# Altium schematic sheet fpga_ddr3l.SchDoc(fpga_ddr3l)
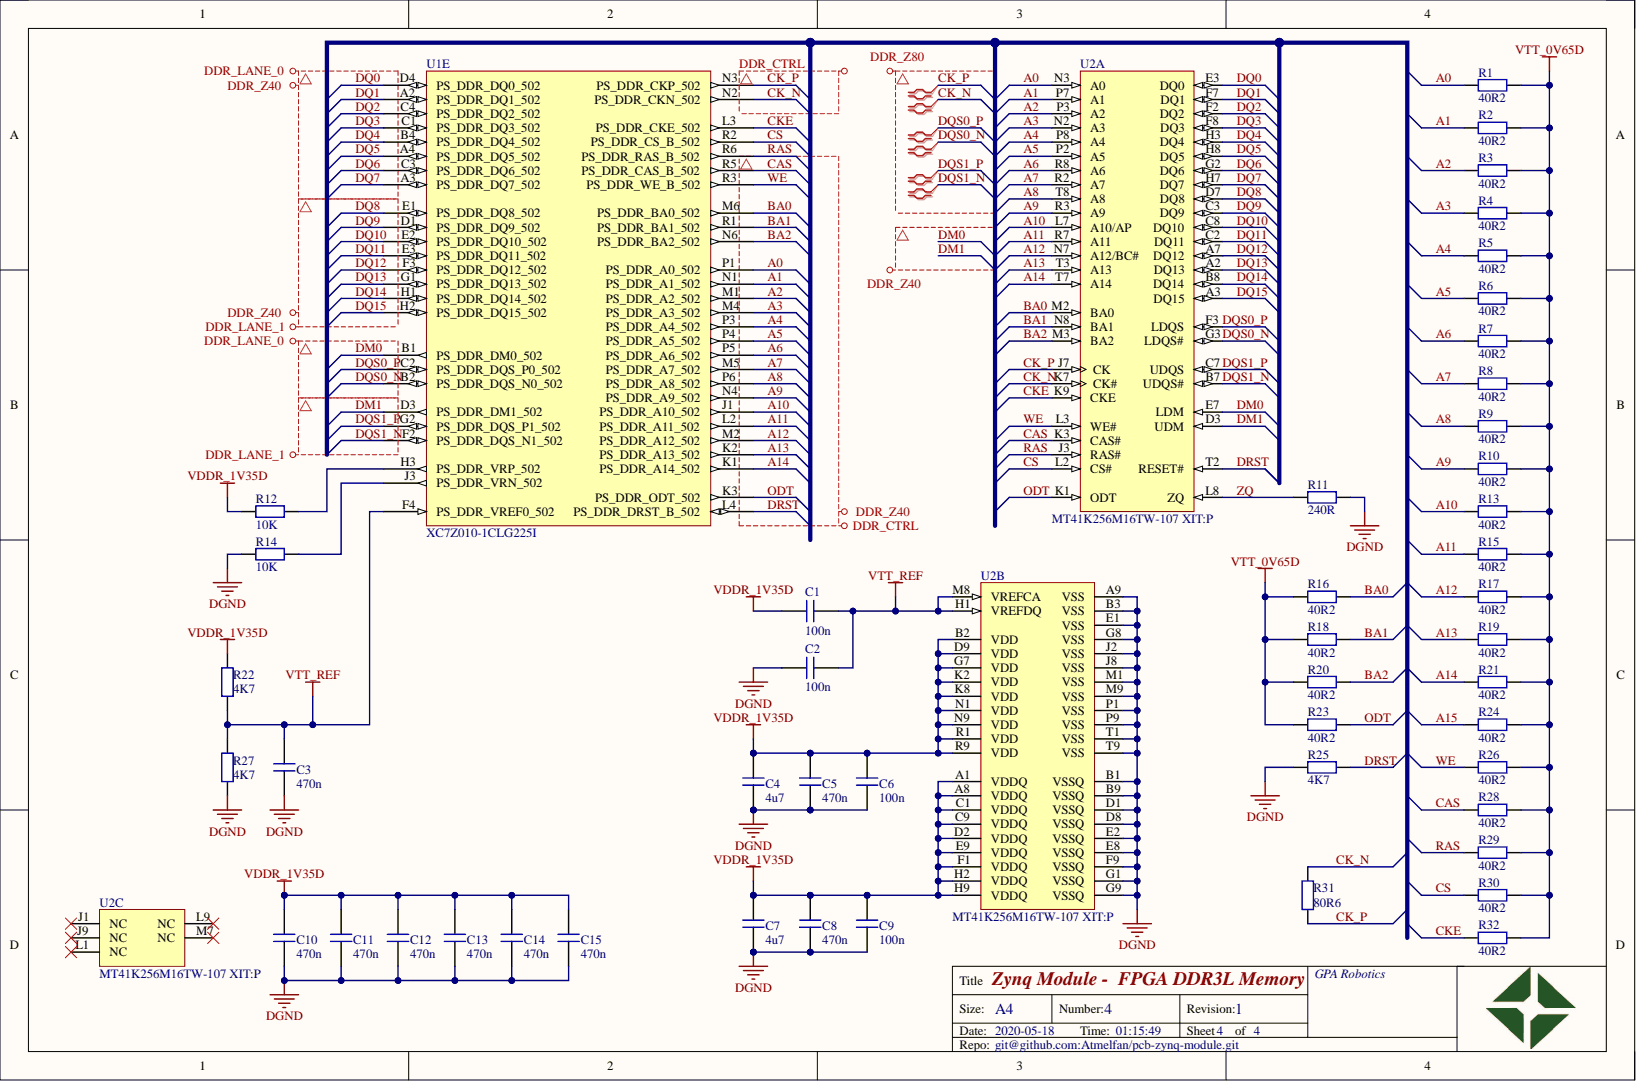
[diagram p0: fpga_ddr3l.SchDoc]

## Parts
C1  value 100n  [CAPC1005X06N]  (hidden comment: cap)
C2  value 100n  [CAPC1005X06N]  (hidden comment: cap)
C3  value 470n  [CAPC1608X08N]  (hidden comment: cap)
C4  value 4u7  [CAPC3216X14N]  (hidden comment: cap)
C5  value 470n  [CAPC1608X08N]  (hidden comment: cap)
C6  value 100n  [CAPC1005X06N]  (hidden comment: cap)
C7  value 4u7  [CAPC3216X14N]  (hidden comment: cap)
C8  value 470n  [CAPC1608X08N]  (hidden comment: cap)
C9  value 100n  [CAPC1005X06N]  (hidden comment: cap)
C10  value 470n  [CAPC1608X08N]  (hidden comment: cap)
C11  value 470n  [CAPC1608X08N]  (hidden comment: cap)
C12  value 470n  [CAPC1608X08N]  (hidden comment: cap)
C13  value 470n  [CAPC1608X08N]  (hidden comment: cap)
C14  value 470n  [CAPC1608X08N]  (hidden comment: cap)
C15  value 470n  [CAPC1608X08N]  (hidden comment: cap)
R1  value 40R2  [RESC1005X04N]  (hidden comment: res)
R2  value 40R2  [RESC1005X04N]  (hidden comment: res)
R3  value 40R2  [RESC1005X04N]  (hidden comment: res)
R4  value 40R2  [RESC1005X04N]  (hidden comment: res)
R5  value 40R2  [RESC1005X04N]  (hidden comment: res)
R6  value 40R2  [RESC1005X04N]  (hidden comment: res)
R7  value 40R2  [RESC1005X04N]  (hidden comment: res)
R8  value 40R2  [RESC1005X04N]  (hidden comment: res)
R9  value 40R2  [RESC1005X04N]  (hidden comment: res)
R10  value 40R2  [RESC1005X04N]  (hidden comment: res)
R11  value 240R  [RESC1005X04N]  (hidden comment: res)
R12  value 10K  [RESC1005X04N]  (hidden comment: res)
R13  value 40R2  [RESC1005X04N]  (hidden comment: res)
R14  value 10K  [RESC1005X04N]  (hidden comment: res)
R15  value 40R2  [RESC1005X04N]  (hidden comment: res)
R16  value 40R2  [RESC1005X04N]  (hidden comment: res)
R17  value 40R2  [RESC1005X04N]  (hidden comment: res)
R18  value 40R2  [RESC1005X04N]  (hidden comment: res)
R19  value 40R2  [RESC1005X04N]  (hidden comment: res)
R20  value 40R2  [RESC1005X04N]  (hidden comment: res)
R21  value 40R2  [RESC1005X04N]  (hidden comment: res)
R22  value 4K7  [RESC1005X04N]  (hidden comment: res)
R23  value 40R2  [RESC1005X04N]  (hidden comment: res)
R24  value 40R2  [RESC1005X04N]  (hidden comment: res)
R25  value 4K7  [RESC1005X04N]  (hidden comment: res)
R26  value 40R2  [RESC1005X04N]  (hidden comment: res)
R27  value 4K7  [RESC1005X04N]  (hidden comment: res)
R28  value 40R2  [RESC1005X04N]  (hidden comment: res)
R29  value 40R2  [RESC1005X04N]  (hidden comment: res)
R30  value 40R2  [RESC1005X04N]  (hidden comment: res)
R31  value 80R6  [RESC1005X04N]  (hidden comment: res)
R32  value 40R2  [RESC1005X04N]  (hidden comment: res)
U1  XC7Z010-1CLG225I  [CLG225]  (part E)
U2  MT41K256M16TW-107 XIT:P  [MICT-TW-96]  (parts A,B,C)

## Nets
DGND: C2.1 C3.1 C4.1 C5.1 C6.1 C7.1 C8.1 C9.1 C10.1 C11.1 C12.1 C13.1 C14.1 C15.1 R11.2 R14.1 R25.2 R27.1 U2.A9(VSS) U2.B1(VSSQ) U2.B3(VSS) U2.B9(VSSQ) U2.D1(VSSQ) U2.D8(VSSQ) U2.E1(VSS) U2.E2(VSSQ) U2.E8(VSSQ) U2.F9(VSSQ) U2.G1(VSSQ) U2.G8(VSS) U2.G9(VSSQ) U2.J2(VSS) U2.J8(VSS) U2.M1(VSS) U2.M9(VSS) U2.P1(VSS) U2.P9(VSS) U2.T1(VSS) U2.T9(VSS)
VDDR_1V35D: C1.1 C4.2 C5.2 C6.2 C7.2 C8.2 C9.2 C10.2 C11.2 C12.2 C13.2 C14.2 C15.2 R12.1 R22.2 U2.A1(VDDQ) U2.A8(VDDQ) U2.B2(VDD) U2.C1(VDDQ) U2.C9(VDDQ) U2.D2(VDDQ) U2.D9(VDD) U2.E9(VDDQ) U2.F1(VDDQ) U2.G7(VDD) U2.H2(VDDQ) U2.H9(VDDQ) U2.K2(VDD) U2.K8(VDD) U2.N1(VDD) U2.N9(VDD) U2.R1(VDD) U2.R9(VDD)
VTT_0V65D: R1.2 R2.2 R3.2 R4.2 R5.2 R6.2 R7.2 R8.2 R9.2 R10.2 R13.2 R15.2 R16.2 R17.2 R18.2 R19.2 R20.2 R21.2 R23.2 R24.2 R26.2 R28.2 R29.2 R30.2 R32.2
VTT_REF: C1.2 C2.2 C3.2 R22.1 R27.2 U1.F4(PS_DDR_VREF0_502) U2.H1(VREFDQ) U2.M8(VREFCA)
A0: R1.1 U1.P1(PS_DDR_A0_502) U2.N3(A0)
A1: R2.1 U1.N1(PS_DDR_A1_502) U2.P7(A1)
A2: R3.1 U1.M1(PS_DDR_A2_502) U2.P3(A2)
A3: R4.1 U1.M4(PS_DDR_A3_502) U2.N2(A3)
A4: R5.1 U1.P3(PS_DDR_A4_502) U2.P8(A4)
A5: R6.1 U1.P4(PS_DDR_A5_502) U2.P2(A5)
A6: R7.1 U1.P5(PS_DDR_A6_502) U2.R8(A6)
A7: R8.1 U1.M5(PS_DDR_A7_502) U2.R2(A7)
A8: R9.1 U1.P6(PS_DDR_A8_502) U2.T8(A8)
A9: R10.1 U1.N4(PS_DDR_A9_502) U2.R3(A9)
A10: R13.1 U1.J1(PS_DDR_A10_502) U2.L7(A10/AP)
A11: R15.1 U1.L2(PS_DDR_A11_502) U2.R7(A11)
A12: R17.1 U1.M2(PS_DDR_A12_502) U2.N7(A12/BC#)
A13: R19.1 U1.K2(PS_DDR_A13_502) U2.T3(A13)
A14: R21.1 U1.K1(PS_DDR_A14_502) U2.T7(A14)
A15: R24.1
BA0: R16.1 U1.M6(PS_DDR_BA0_502) U2.M2(BA0)
BA1: R18.1 U1.R1(PS_DDR_BA1_502) U2.N8(BA1)
BA2: R20.1 U1.N6(PS_DDR_BA2_502) U2.M3(BA2)
CAS: R28.1 U1.R5(PS_DDR_CAS_B_502) U2.K3(CAS#)
CK_N: R31.1 U1.N2(PS_DDR_CKN_502) U2.K7(CK#)
CK_P: R31.2 U1.N3(PS_DDR_CKP_502) U2.J7(CK)
CKE: R32.1 U1.L3(PS_DDR_CKE_502) U2.K9(CKE)
CS: R30.1 U1.R2(PS_DDR_CS_B_502) U2.L2(CS#)
DM0: U1.B1(PS_DDR_DM0_502) U2.E7(LDM)
DM1: U1.D3(PS_DDR_DM1_502) U2.D3(UDM)
DQ0: U1.D4(PS_DDR_DQ0_502) U2.E3(DQ0)
DQ1: U1.A2(PS_DDR_DQ1_502) U2.F7(DQ1)
DQ2: U1.C4(PS_DDR_DQ2_502) U2.F2(DQ2)
DQ3: U1.C1(PS_DDR_DQ3_502) U2.F8(DQ3)
DQ4: U1.B4(PS_DDR_DQ4_502) U2.H3(DQ4)
DQ5: U1.A4(PS_DDR_DQ5_502) U2.H8(DQ5)
DQ6: U1.C3(PS_DDR_DQ6_502) U2.G2(DQ6)
DQ7: U1.A3(PS_DDR_DQ7_502) U2.H7(DQ7)
DQ8: U1.E1(PS_DDR_DQ8_502) U2.D7(DQ8)
DQ9: U1.D1(PS_DDR_DQ9_502) U2.C3(DQ9)
DQ10: U1.E2(PS_DDR_DQ10_502) U2.C8(DQ10)
DQ11: U1.E3(PS_DDR_DQ11_502) U2.C2(DQ11)
DQ12: U1.F3(PS_DDR_DQ12_502) U2.A7(DQ12)
DQ13: U1.G1(PS_DDR_DQ13_502) U2.A2(DQ13)
DQ14: U1.H1(PS_DDR_DQ14_502) U2.B8(DQ14)
DQ15: U1.H2(PS_DDR_DQ15_502) U2.A3(DQ15)
DQS0_N: U1.B2(PS_DDR_DQS_N0_502) U2.G3(LDQS#)
DQS0_P: U1.C2(PS_DDR_DQS_P0_502) U2.F3(LDQS)
DQS1_N: U1.F2(PS_DDR_DQS_N1_502) U2.B7(UDQS#)
DQS1_P: U1.G2(PS_DDR_DQS_P1_502) U2.C7(UDQS)
DRST: R25.1 U1.L4(PS_DDR_DRST_B_502) U2.T2(RESET#)
ODT: R23.1 U1.K3(PS_DDR_ODT_502) U2.K1(ODT)
RAS: R29.1 U1.R6(PS_DDR_RAS_B_502) U2.J3(RAS#)
WE: R26.1 U1.R3(PS_DDR_WE_B_502) U2.L3(WE#)
ZQ: R11.1 U2.L8(ZQ)
NetR12_2: R12.2 U1.H3(PS_DDR_VRP_502)
NetR14_2: R14.2 U1.J3(PS_DDR_VRN_502)

Not connected: U2 J1(NC) J9(NC) L1(NC) L9(NC) M7(NC)

## Library data of the parts
C1-C15 = cap: Capacitor; Component Kind: Standard; Library Name: lib_passives.IntLib
R1-R32 = res: Resistor; Component Kind: Standard; Library Name: lib_passives.IntLib
U1 = CMP-0382-00001-1: 667 MHz Zynq™-7000 AP SoC, 88 PS I/O, 54 FPGA I/O, 225-Ball BGA, Speed Grade 1, Industrial Grade, Pb-Free; Extensible Block RAM (# 36Kb Blocks): 240 KB (60); Flip Flops: 35200; LUTs: 17600; Speed Grade: -1; Code_JEDEC: MO-275-HHAC-1; Component Kind: Standard; ComponentLink1Description: Datasheet; ComponentLink1URL: http://www.xilinx.com/support/documentation/data_sheets/ds190-Zynq-7000-Overview.pdf; ComponentLink2Description: Package Specification; ComponentLink2URL: http://www.xilinx.com/support/documentation/user_guides/ug865-Zynq-7000-Pkg-Pinout.pdf; ComponentLink3Description: DC and AC Switching Characteristics; ComponentLink3URL: http://www.xilinx.com/support/documentation/data_sheets/ds187-XC7Z010-XC7Z020-Data-Sheet.pdf; ComponentLink4Description: Package File; ComponentLink4URL: http://www.xilinx.com/support/packagefiles/z7packages/xc7z010clg225pkg.txt; ComponentLink5Description: Packaging and Pinout; ComponentLink5URL: http://www.xilinx.com/support/documentation/user_guides/ug865-Zynq-7000-Pkg-Pinout.pdf; ComponentLink6Description: PCB and Layout Guide; ComponentLink6URL: http://www.xilinx.com/support/documentation/user_guides/ug483_7Series_PCB.pdf; DatasheetVersion: v1.2; Generic Part Number: XC7Z010; Library Name: Altium Content Vault; Mounting Technology: Surface Mount; PackageDescription: Wire-Bond Chip-Scale BGA, Body 13 x 13 mm, 0.8 mm Pitch; PackageReference: CLG225; PackageVersion: Rev 1.2, 02/2013; PartNumber: XC7Z010-1CLG225I; RoHS: Pb-Free
U2 = CMP-2000-07474-1: DDR3L SDRAM, 4 GB, 933 MHz, 1.283 to 1.45 V, -40 to 95 degC, 96-Pin FBGA, RoHs, Tray; Manufacturer: Micron; Access Time: 1.07ns; Address Bus Width: 15b; Case\/Package: TFBGA; Data Bus Width: 16b; Density: 4Gb; Frequency: 933MHz; Interface: Parallel; Memory Type: RAM; Component Kind: Standard; Contact Plating: Silver; Datasheet URL: https://www.micron.com/~/media/documents/products/data-sheet/dram/ddr3/4gb_1_35v_ddr3l_xit_addendum.pdf; Datasheet Version: Rev. A, 02/2016; Library Name: Altium Content Vault; Manufacturer URL: http://www.micron.com/; Max Frequency: 933MHz; Max Operating Temperature: 95degC; Min Operating Temperature: -40degC; Mount: SurfaceMount; Mounting Technology: SurfaceMount; Package Description: 96-Ball Fine Pitch Ball Grid Array, Body 14 x 8 mm, Pitch 0.8 mm; Package Reference: TW-96; Packaging: Tray; RoHSCompliant: true
